# Altium schematic sheet DDR3 Interface.SchDoc
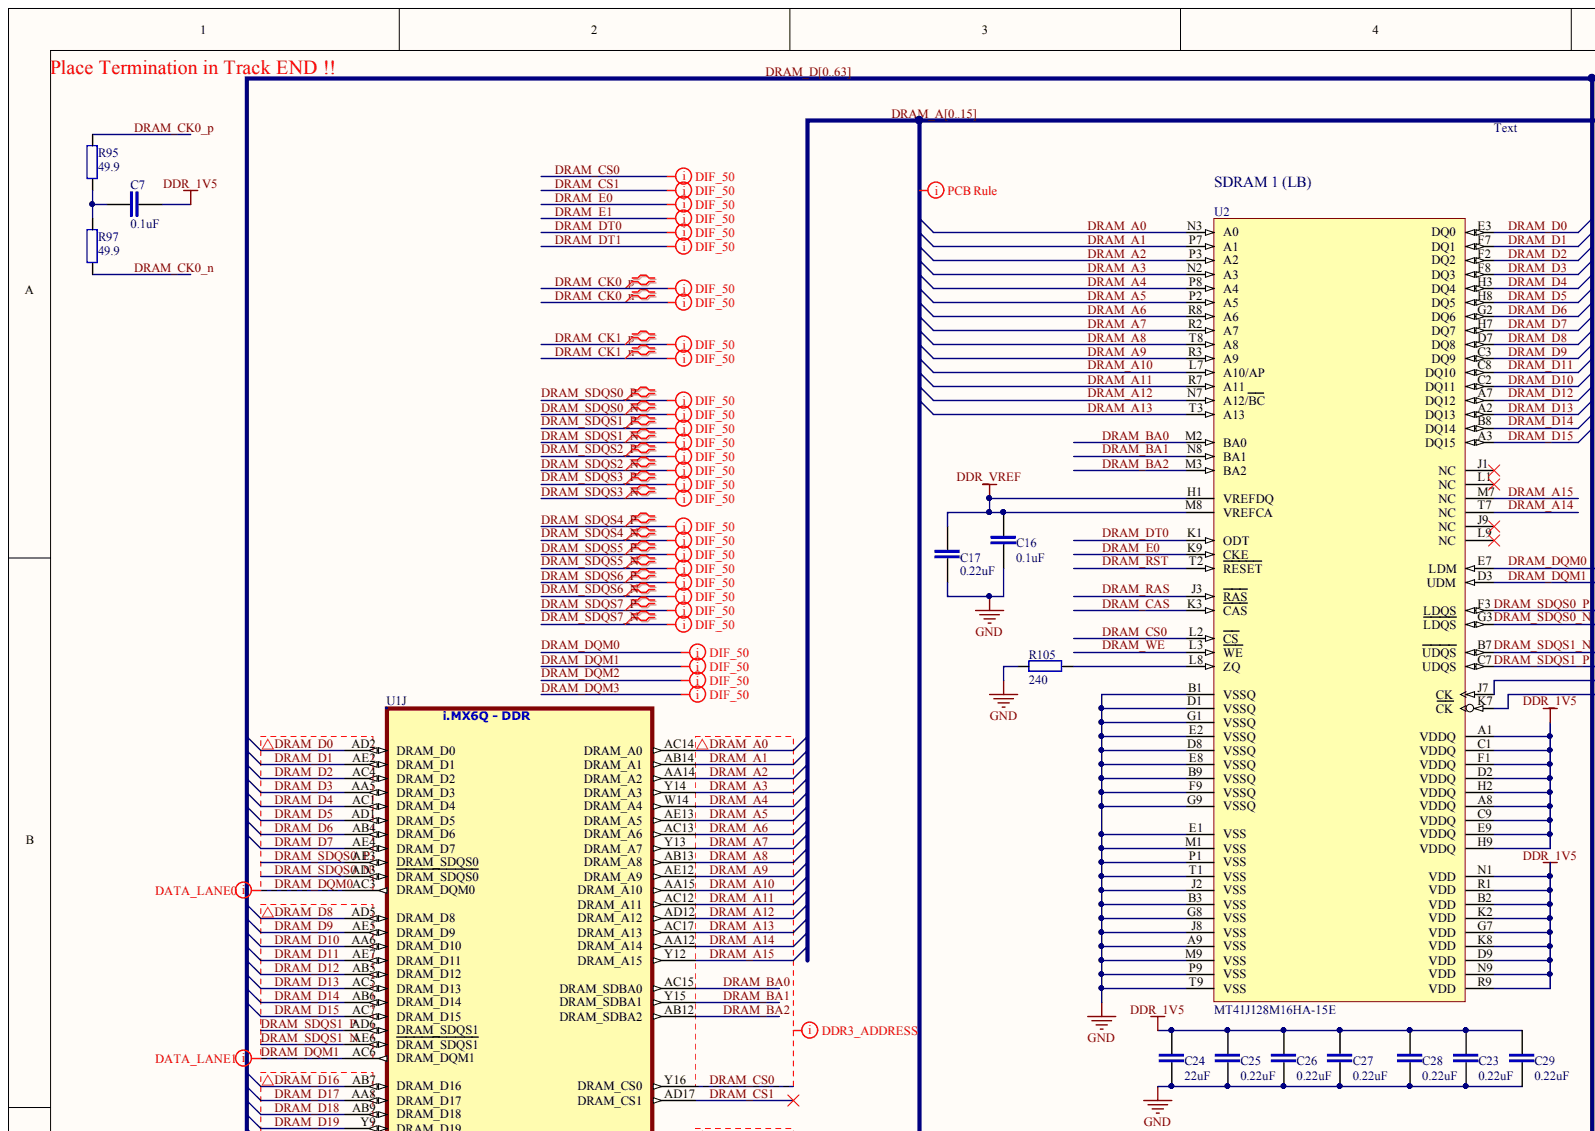
[diagram p0: DDR3 Interface.SchDoc, top left]
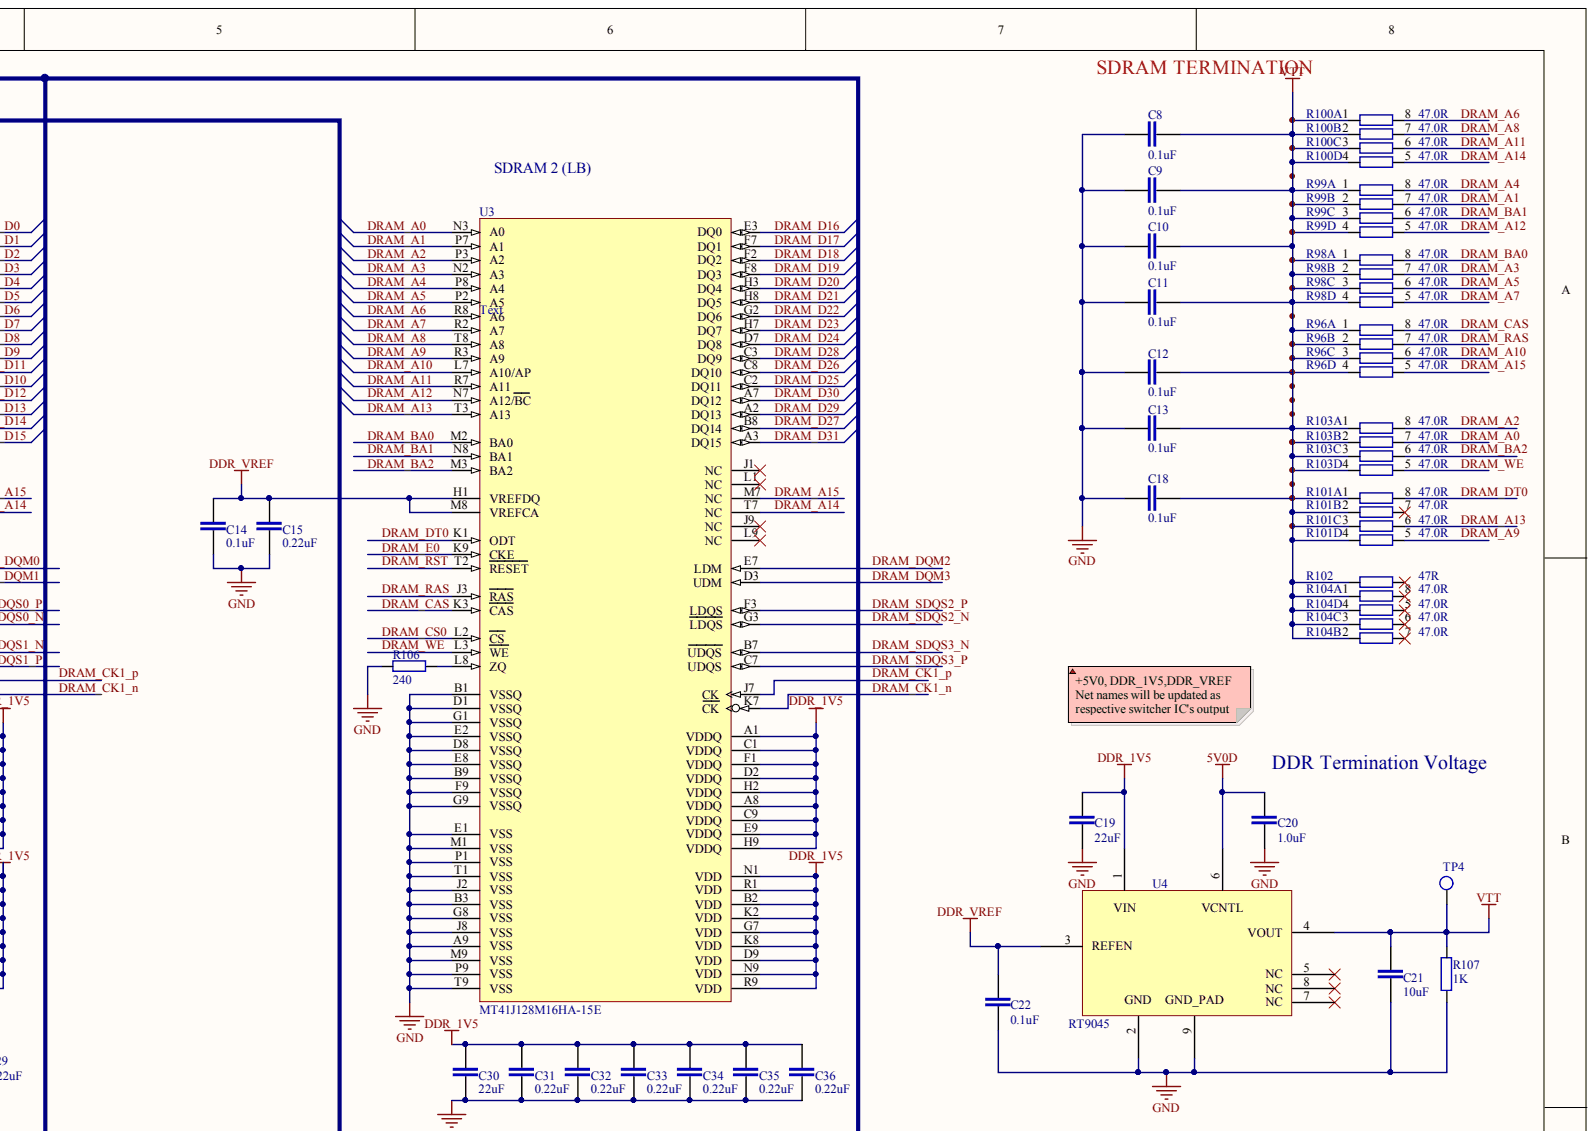
[diagram p1: DDR3 Interface.SchDoc, top right]
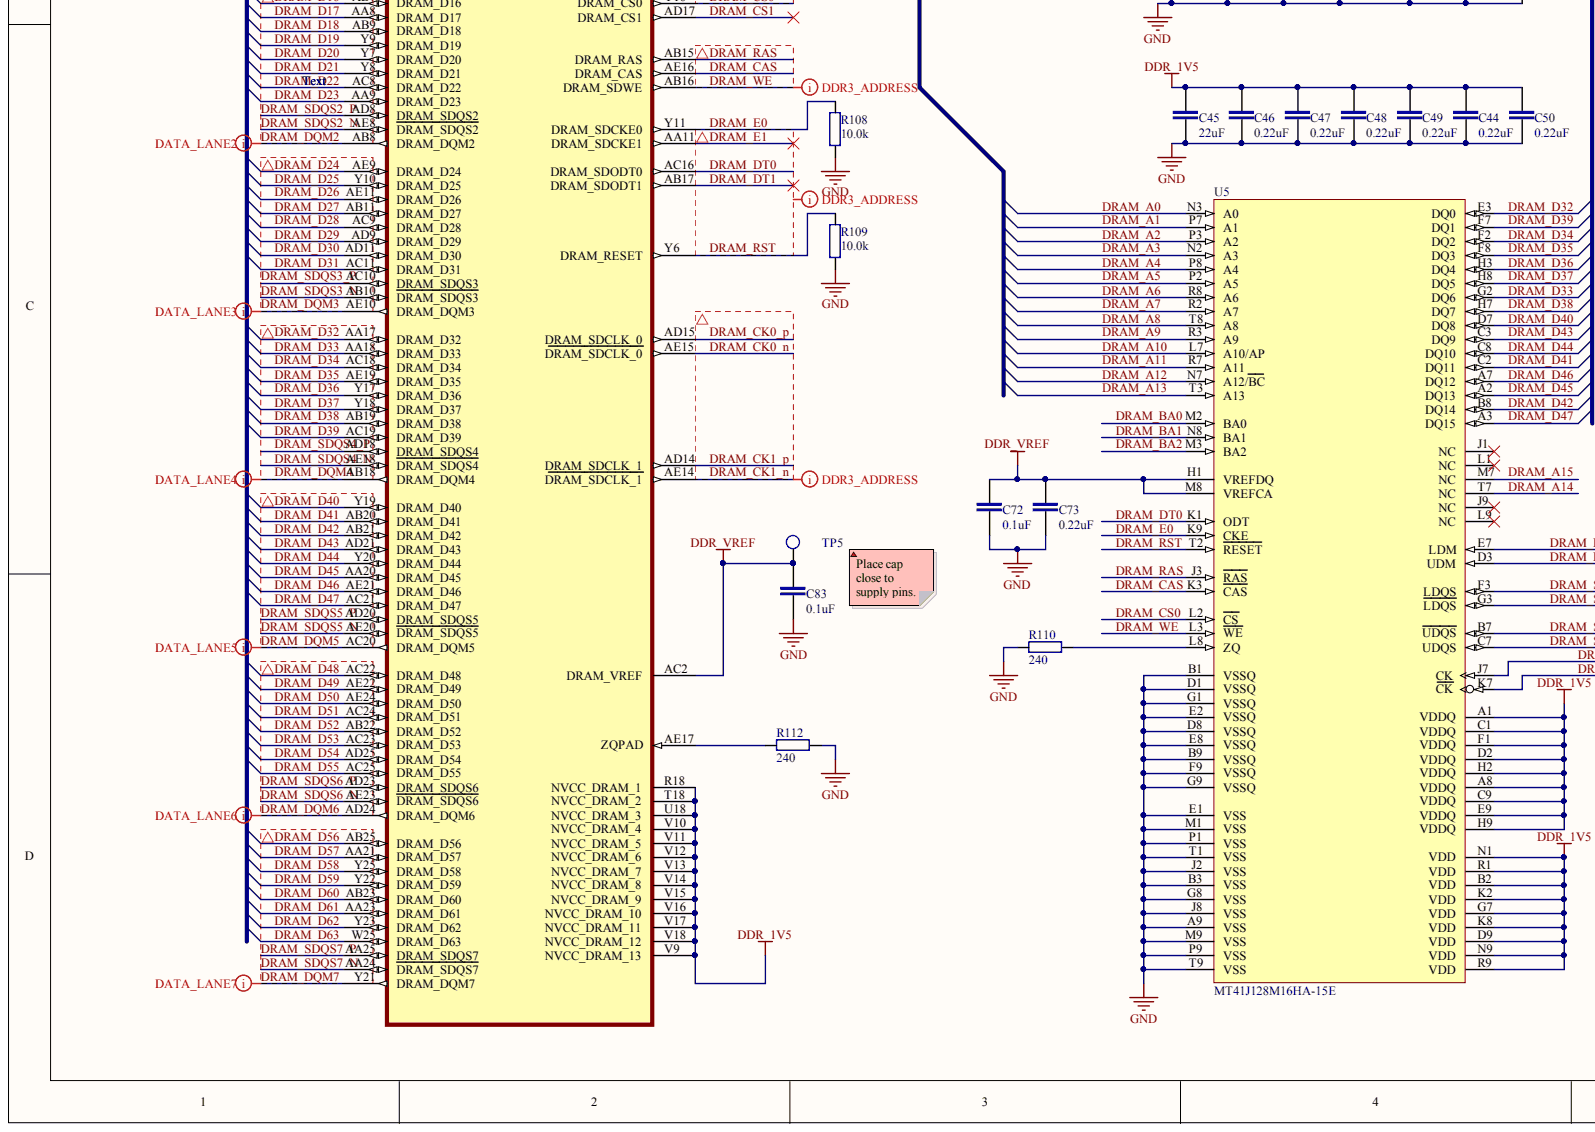
[diagram p2: DDR3 Interface.SchDoc, bottom left]
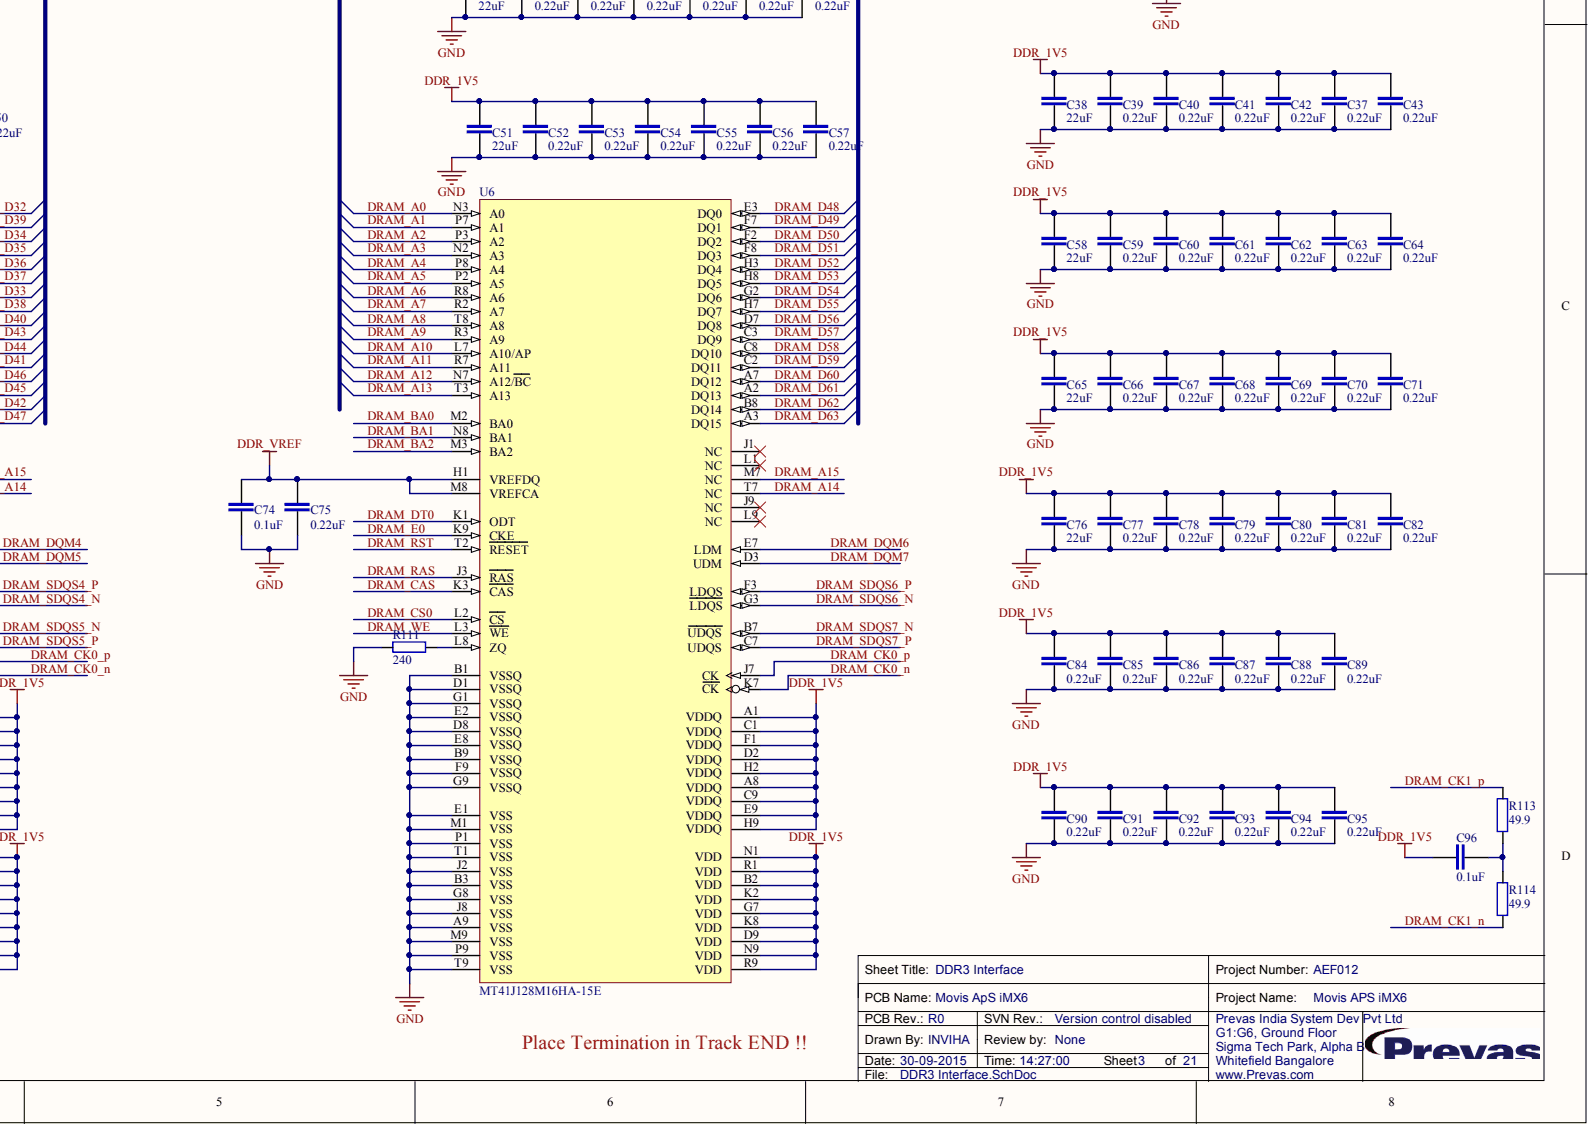
[diagram p3: DDR3 Interface.SchDoc, bottom right]

## Parts
C7  0.1uF  [CAPC1005X55N]
C8  0.1uF  [CAPC1005X55N]
C9  0.1uF  [CAPC1005X55N]
C10  0.1uF  [CAPC1005X55N]
C11  0.1uF  [CAPC1005X55N]
C12  0.1uF  [CAPC1005X55N]
C13  0.1uF  [CAPC1005X55N]
C14  0.1uF  [CAPC1005X55N]
C15  0.22uF  [CAPC1005X55N]
C16  0.1uF  [CAPC1005X55N]
C17  0.22uF  [CAPC1005X55N]
C18  0.1uF  [CAPC1005X55N]
C19  22uF  [CAPC3216X180N]
C20  1.0uF  [CAPC1608X90N]
C21  10uF  [CAPC1608X95N]
C22  0.1uF  [CAPC1005X55N]
C23  0.22uF  [CAPC1005X55N]
C24  22uF  [CAPC3216X180N]
C25  0.22uF  [CAPC1005X55N]
C26  0.22uF  [CAPC1005X55N]
C27  0.22uF  [CAPC1005X55N]
C28  0.22uF  [CAPC1005X55N]
C29  0.22uF  [CAPC1005X55N]
C30  22uF  [CAPC3216X180N]
C31  0.22uF  [CAPC1005X55N]
C32  0.22uF  [CAPC1005X55N]
C33  0.22uF  [CAPC1005X55N]
C34  0.22uF  [CAPC1005X55N]
C35  0.22uF  [CAPC1005X55N]
C36  0.22uF  [CAPC1005X55N]
C37  0.22uF  [CAPC1005X55N]
C38  22uF  [CAPC3216X180N]
C39  0.22uF  [CAPC1005X55N]
C40  0.22uF  [CAPC1005X55N]
C41  0.22uF  [CAPC1005X55N]
C42  0.22uF  [CAPC1005X55N]
C43  0.22uF  [CAPC1005X55N]
C44  0.22uF  [CAPC1005X55N]
C45  22uF  [CAPC3216X180N]
C46  0.22uF  [CAPC1005X55N]
C47  0.22uF  [CAPC1005X55N]
C48  0.22uF  [CAPC1005X55N]
C49  0.22uF  [CAPC1005X55N]
C50  0.22uF  [CAPC1005X55N]
C51  22uF  [CAPC3216X180N]
C52  0.22uF  [CAPC1005X55N]
C53  0.22uF  [CAPC1005X55N]
C54  0.22uF  [CAPC1005X55N]
C55  0.22uF  [CAPC1005X55N]
C56  0.22uF  [CAPC1005X55N]
C57  0.22uF  [CAPC1005X55N]
C58  22uF  [CAPC3216X180N]
C59  0.22uF  [CAPC1005X55N]
C60  0.22uF  [CAPC1005X55N]
C61  0.22uF  [CAPC1005X55N]
C62  0.22uF  [CAPC1005X55N]
C63  0.22uF  [CAPC1005X55N]
C64  0.22uF  [CAPC1005X55N]
C65  22uF  [CAPC3216X180N]
C66  0.22uF  [CAPC1005X55N]
C67  0.22uF  [CAPC1005X55N]
C68  0.22uF  [CAPC1005X55N]
C69  0.22uF  [CAPC1005X55N]
C70  0.22uF  [CAPC1005X55N]
C71  0.22uF  [CAPC1005X55N]
C72  0.1uF  [CAPC1005X55N]
C73  0.22uF  [CAPC1005X55N]
C74  0.1uF  [CAPC1005X55N]
C75  0.22uF  [CAPC1005X55N]
C76  22uF  [CAPC3216X180N]
C77  0.22uF  [CAPC1005X55N]
C78  0.22uF  [CAPC1005X55N]
C79  0.22uF  [CAPC1005X55N]
C80  0.22uF  [CAPC1005X55N]
C81  0.22uF  [CAPC1005X55N]
C82  0.22uF  [CAPC1005X55N]
C83  0.1uF  [CAPC1005X55N]
C84  0.22uF  [CAPC1005X55N]
C85  0.22uF  [CAPC1005X55N]
C86  0.22uF  [CAPC1005X55N]
C87  0.22uF  [CAPC1005X55N]
C88  0.22uF  [CAPC1005X55N]
C89  0.22uF  [CAPC1005X55N]
C90  0.22uF  [CAPC1005X55N]
C91  0.22uF  [CAPC1005X55N]
C92  0.22uF  [CAPC1005X55N]
C93  0.22uF  [CAPC1005X55N]
C94  0.22uF  [CAPC1005X55N]
C95  0.22uF  [CAPC1005X55N]
C96  0.1uF  [CAPC1005X55N]
R95  49.9  [RESC1005X40N]
R96  47.0R  [RESCAXS50P100X200X45-8N]  (parts A,B,C,D)
R97  49.9  [RESC1005X40N]
R98  47.0R  [RESCAXS50P100X200X45-8N]  (parts A,B,C,D)
R99  47.0R  [RESCAXS50P100X200X45-8N]  (parts A,B,C,D)
R100  47.0R  [RESCAXS50P100X200X45-8N]  (parts A,B,C,D)
R101  47.0R  [RESCAXS50P100X200X45-8N]  (parts A,B,C,D)
R102  47R  [RESC1608X55N]
R103  47.0R  [RESCAXS50P100X200X45-8N]  (parts A,B,C,D)
R104  47.0R  [RESCAXS50P100X200X45-8N]  (parts A,B,C,D)
R105  240  [RESC1005X40N]
R106  240  [RESC1005X40N]
R107  1K  [RESC1608X55N]
R108  10.0k  [RESC1005X40N]
R109  10.0k  [RESC1005X40N]
R110  240  [RESC1005X40N]
R111  240  [RESC1005X40N]
R112  240  [RESC1005X40N]
R113  49.9  [RESC1005X40N]
R114  49.9  [RESC1005X40N]
TP4  Testpoint  [tp_smd]  (value not drawn)  (no BOM)
TP5  Testpoint  [TP_SMD]  (value not drawn)  (no BOM)
U1  MCIMX6Q6AVT10AC  [BGA624C80P25X25_2100X2100X160]  (value not drawn)  (part J)
U2  MT41J128M16HA-15E  [BGA96C80P9X16_900X1400X120]
U3  MT41J128M16HA-15E  [BGA96C80P9X16_900X1400X120]
U4  RT9045  [SOIC127P600X175-9N]
U5  MT41J128M16HA-15E  [BGA96C80P9X16_900X1400X120]
U6  MT41J128M16HA-15E  [BGA96C80P9X16_900X1400X120]

## Nets
5V0D: C20.2 U4.6
DDR_1V5: C7.1 C19.2 C23.2 C24.2 C25.2 C26.2 C27.2 C28.2 C29.2 C30.2 C31.2 C32.2 C33.2 C34.2 C35.2 C36.2 C37.2 C38.2 C39.2 C40.2 C41.2 C42.2 C43.2 C44.2 C45.2 C46.2 C47.2 C48.2 C49.2 C50.2 C51.2 C52.2 C53.2 C54.2 C55.2 C56.2 C57.2 C58.2 C59.2 C60.2 C61.2 C62.2 C63.2 C64.2 C65.2 C66.2 C67.2 C68.2 C69.2 C70.2 C71.2 C76.2 C77.2 C78.2 C79.2 C80.2 C81.2 C82.2 C84.2 C85.2 C86.2 C87.2 C88.2 C89.2 C90.2 C91.2 C92.2 C93.2 C94.2 C95.2 C96.2 U1.R18(NVCC_DRAM_1) U1.T18(NVCC_DRAM_2) U1.U18(NVCC_DRAM_3) U1.V9(NVCC_DRAM_13) U1.V10(NVCC_DRAM_4) U1.V11(NVCC_DRAM_5) U1.V12(NVCC_DRAM_6) U1.V13(NVCC_DRAM_7) U1.V14(NVCC_DRAM_8) U1.V15(NVCC_DRAM_9) U1.V16(NVCC_DRAM_10) U1.V17(NVCC_DRAM_11) U1.V18(NVCC_DRAM_12) U2.A1(VDDQ) U2.A8(VDDQ) U2.B2(VDD) U2.C1(VDDQ) U2.C9(VDDQ) U2.D2(VDDQ) U2.D9(VDD) U2.E9(VDDQ) U2.F1(VDDQ) U2.G7(VDD) U2.H2(VDDQ) U2.H9(VDDQ) U2.K2(VDD) U2.K8(VDD) U2.N1(VDD) U2.N9(VDD) U2.R1(VDD) U2.R9(VDD) U3.A1(VDDQ) U3.A8(VDDQ) U3.B2(VDD) U3.C1(VDDQ) U3.C9(VDDQ) U3.D2(VDDQ) U3.D9(VDD) U3.E9(VDDQ) U3.F1(VDDQ) U3.G7(VDD) U3.H2(VDDQ) U3.H9(VDDQ) U3.K2(VDD) U3.K8(VDD) U3.N1(VDD) U3.N9(VDD) U3.R1(VDD) U3.R9(VDD) U4.1 U5.A1(VDDQ) U5.A8(VDDQ) U5.B2(VDD) U5.C1(VDDQ) U5.C9(VDDQ) U5.D2(VDDQ) U5.D9(VDD) U5.E9(VDDQ) U5.F1(VDDQ) U5.G7(VDD) U5.H2(VDDQ) U5.H9(VDDQ) U5.K2(VDD) U5.K8(VDD) U5.N1(VDD) U5.N9(VDD) U5.R1(VDD) U5.R9(VDD) U6.A1(VDDQ) U6.A8(VDDQ) U6.B2(VDD) U6.C1(VDDQ) U6.C9(VDDQ) U6.D2(VDDQ) U6.D9(VDD) U6.E9(VDDQ) U6.F1(VDDQ) U6.G7(VDD) U6.H2(VDDQ) U6.H9(VDDQ) U6.K2(VDD) U6.K8(VDD) U6.N1(VDD) U6.N9(VDD) U6.R1(VDD) U6.R9(VDD)
DDR_VREF: C14.2 C15.2 C16.2 C17.2 C22.2 C72.2 C73.2 C74.2 C75.2 C83.2 TP5.1 U1.AC2(DRAM_VREF) U2.H1(VREFDQ) U2.M8(VREFCA) U3.H1(VREFDQ) U3.M8(VREFCA) U4.3(REFEN) U5.H1(VREFDQ) U5.M8(VREFCA) U6.H1(VREFDQ) U6.M8(VREFCA)
GND: C8.2 C9.2 C10.2 C11.2 C12.2 C13.2 C14.1 C15.1 C16.1 C17.1 C18.2 C19.1 C20.1 C21.1 C22.1 C23.1 C24.1 C25.1 C26.1 C27.1 C28.1 C29.1 C30.1 C31.1 C32.1 C33.1 C34.1 C35.1 C36.1 C37.1 C38.1 C39.1 C40.1 C41.1 C42.1 C43.1 C44.1 C45.1 C46.1 C47.1 C48.1 C49.1 C50.1 C51.1 C52.1 C53.1 C54.1 C55.1 C56.1 C57.1 C58.1 C59.1 C60.1 C61.1 C62.1 C63.1 C64.1 C65.1 C66.1 C67.1 C68.1 C69.1 C70.1 C71.1 C72.1 C73.1 C74.1 C75.1 C76.1 C77.1 C78.1 C79.1 C80.1 C81.1 C82.1 C83.1 C84.1 C85.1 C86.1 C87.1 C88.1 C89.1 C90.1 C91.1 C92.1 C93.1 C94.1 C95.1 R105.1 R106.1 R107.1 R108.1 R109.1 R110.1 R111.1 R112.2 U2.A9(VSS) U2.B1(VSSQ) U2.B3(VSS) U2.B9(VSSQ) U2.D1(VSSQ) U2.D8(VSSQ) U2.E1(VSS) U2.E2(VSSQ) U2.E8(VSSQ) U2.F9(VSSQ) U2.G1(VSSQ) U2.G8(VSS) U2.G9(VSSQ) U2.J2(VSS) U2.J8(VSS) U2.M1(VSS) U2.M9(VSS) U2.P1(VSS) U2.P9(VSS) U2.T1(VSS) U2.T9(VSS) U3.A9(VSS) U3.B1(VSSQ) U3.B3(VSS) U3.B9(VSSQ) U3.D1(VSSQ) U3.D8(VSSQ) U3.E1(VSS) U3.E2(VSSQ) U3.E8(VSSQ) U3.F9(VSSQ) U3.G1(VSSQ) U3.G8(VSS) U3.G9(VSSQ) U3.J2(VSS) U3.J8(VSS) U3.M1(VSS) U3.M9(VSS) U3.P1(VSS) U3.P9(VSS) U3.T1(VSS) U3.T9(VSS) U4.2 U4.9 U5.A9(VSS) U5.B1(VSSQ) U5.B3(VSS) U5.B9(VSSQ) U5.D1(VSSQ) U5.D8(VSSQ) U5.E1(VSS) U5.E2(VSSQ) U5.E8(VSSQ) U5.F9(VSSQ) U5.G1(VSSQ) U5.G8(VSS) U5.G9(VSSQ) U5.J2(VSS) U5.J8(VSS) U5.M1(VSS) U5.M9(VSS) U5.P1(VSS) U5.P9(VSS) U5.T1(VSS) U5.T9(VSS) U6.A9(VSS) U6.B1(VSSQ) U6.B3(VSS) U6.B9(VSSQ) U6.D1(VSSQ) U6.D8(VSSQ) U6.E1(VSS) U6.E2(VSSQ) U6.E8(VSSQ) U6.F9(VSSQ) U6.G1(VSSQ) U6.G8(VSS) U6.G9(VSSQ) U6.J2(VSS) U6.J8(VSS) U6.M1(VSS) U6.M9(VSS) U6.P1(VSS) U6.P9(VSS) U6.T1(VSS) U6.T9(VSS)
VTT: C8.1 C9.1 C10.1 C11.1 C12.1 C13.1 C18.1 C21.2 R96.1 R96.2 R96.3 R96.4 R98.1 R98.2 R98.3 R98.4 R99.1 R99.2 R99.3 R99.4 R100.1 R100.2 R100.3 R100.4 R101.1 R101.2 R101.3 R101.4 R102.1 R103.1 R103.2 R103.3 R103.4 R104.1 R104.2 R104.3 R104.4 R107.2 TP4.1 U4.4(VOUT)
DRAM_A0: R103.7 U1.AC14(DRAM_A0) U2.N3(A0) U3.N3(A0) U5.N3(A0) U6.N3(A0)  [also labelled DRAM_A]
DRAM_A1: R99.7 U1.AB14(DRAM_A1) U2.P7(A1) U3.P7(A1) U5.P7(A1) U6.P7(A1)  [also labelled DRAM_A]
DRAM_A2: R103.8 U1.AA14(DRAM_A2) U2.P3(A2) U3.P3(A2) U5.P3(A2) U6.P3(A2)  [also labelled DRAM_A]
DRAM_A3: R98.7 U1.Y14(DRAM_A3) U2.N2(A3) U3.N2(A3) U5.N2(A3) U6.N2(A3)  [also labelled DRAM_A]
DRAM_A4: R99.8 U1.W14(DRAM_A4) U2.P8(A4) U3.P8(A4) U5.P8(A4) U6.P8(A4)  [also labelled DRAM_A]
DRAM_A5: R98.6 U1.AE13(DRAM_A5) U2.P2(A5) U3.P2(A5) U5.P2(A5) U6.P2(A5)  [also labelled DRAM_A]
DRAM_A6: R100.8 U1.AC13(DRAM_A6) U2.R8(A6) U3.R8(Text) U5.R8(A6) U6.R8(A6)  [also labelled DRAM_A]
DRAM_A7: R98.5 U1.Y13(DRAM_A7) U2.R2(A7) U3.R2(A7) U5.R2(A7) U6.R2(A7)  [also labelled DRAM_A]
DRAM_A8: R100.7 U1.AB13(DRAM_A8) U2.T8(A8) U3.T8(A8) U5.T8(A8) U6.T8(A8)  [also labelled DRAM_A]
DRAM_A9: R101.5 U1.AE12(DRAM_A9) U2.R3(A9) U3.R3(A9) U5.R3(A9) U6.R3(A9)  [also labelled DRAM_A]
DRAM_A10: R96.6 U1.AA15(DRAM_A10) U2.L7(A10/AP) U3.L7(A10/AP) U5.L7(A10/AP) U6.L7(A10/AP)  [also labelled DRAM_A]
DRAM_A11: R100.6 U1.AC12(DRAM_A11) U2.R7(A11) U3.R7(A11) U5.R7(A11) U6.R7(A11)  [also labelled DRAM_A]
DRAM_A12: R99.5 U1.AD12(DRAM_A12) U2.N7(A12/BC) U3.N7(A12/BC) U5.N7(A12/BC) U6.N7(A12/BC)  [also labelled DRAM_A]
DRAM_A13: R101.6 U1.AC17(DRAM_A13) U2.T3(A13) U3.T3(A13) U5.T3(A13) U6.T3(A13)  [also labelled DRAM_A]
DRAM_A14: R100.5 U1.AA12(DRAM_A14) U2.T7(NC) U3.T7(NC) U5.T7(NC) U6.T7(NC)  [also labelled DRAM_A]
DRAM_A15: R96.5 U1.Y12(DRAM_A15) U2.M7(NC) U3.M7(NC) U5.M7(NC) U6.M7(NC)  [also labelled DRAM_A]
DRAM_BA0: R98.8 U1.AC15(DRAM_SDBA0) U2.M2(BA0) U3.M2(BA0) U5.M2(BA0) U6.M2(BA0)
DRAM_BA1: R99.6 U1.Y15(DRAM_SDBA1) U2.N8(BA1) U3.N8(BA1) U5.N8(BA1) U6.N8(BA1)
DRAM_BA2: R103.6 U1.AB12(DRAM_SDBA2) U2.M3(BA2) U3.M3(BA2) U5.M3(BA2) U6.M3(BA2)
DRAM_CAS: R96.8 U1.AE16(DRAM_CAS) U2.K3(CAS) U3.K3(CAS) U5.K3(CAS) U6.K3(CAS)
DRAM_CK0_N: R97.1 U1.AE15(DRAM_SDCLK_0) U5.K7(CK) U6.K7(CK)
DRAM_CK0_P: R95.2 U1.AD15(DRAM_SDCLK_0) U5.J7(CK) U6.J7(CK)
DRAM_CK1_N: R114.1 U1.AE14(DRAM_SDCLK_1) U2.K7(CK) U3.K7(CK)
DRAM_CK1_P: R113.2 U1.AD14(DRAM_SDCLK_1) U2.J7(CK) U3.J7(CK)
DRAM_CS0: U1.Y16(DRAM_CS0) U2.L2(CS) U3.L2(CS) U5.L2(CS) U6.L2(CS)
DRAM_CS1: U1.AD17(DRAM_CS1)
DRAM_D0: U1.AD2(DRAM_D0) U2.E3(DQ0)  [also labelled DRAM_D]
DRAM_D1: U1.AE2(DRAM_D1) U2.F7(DQ1)  [also labelled DRAM_D]
DRAM_D2: U1.AC4(DRAM_D2) U2.F2(DQ2)  [also labelled DRAM_D]
DRAM_D3: U1.AA5(DRAM_D3) U2.F8(DQ3)  [also labelled DRAM_D]
DRAM_D4: U1.AC1(DRAM_D4) U2.H3(DQ4)  [also labelled DRAM_D]
DRAM_D5: U1.AD1(DRAM_D5) U2.H8(DQ5)  [also labelled DRAM_D]
DRAM_D6: U1.AB4(DRAM_D6) U2.G2(DQ6)  [also labelled DRAM_D]
DRAM_D7: U1.AE4(DRAM_D7) U2.H7(DQ7)  [also labelled DRAM_D]
DRAM_D8: U1.AD5(DRAM_D8) U2.D7(DQ8)  [also labelled DRAM_D]
DRAM_D9: U1.AE5(DRAM_D9) U2.C3(DQ9)  [also labelled DRAM_D]
DRAM_D10: U1.AA6(DRAM_D10) U2.C2(DQ11)  [also labelled DRAM_D]
DRAM_D11: U1.AE7(DRAM_D11) U2.C8(DQ10)  [also labelled DRAM_D]
DRAM_D12: U1.AB5(DRAM_D12) U2.A7(DQ12)  [also labelled DRAM_D]
DRAM_D13: U1.AC5(DRAM_D13) U2.A2(DQ13)  [also labelled DRAM_D]
DRAM_D14: U1.AB6(DRAM_D14) U2.B8(DQ14)  [also labelled DRAM_D]
DRAM_D15: U1.AC7(DRAM_D15) U2.A3(DQ15)  [also labelled DRAM_D]
DRAM_D16: U1.AB7(DRAM_D16) U3.E3(DQ0)  [also labelled DRAM_D]
DRAM_D17: U1.AA8(DRAM_D17) U3.F7(DQ1)  [also labelled DRAM_D]
DRAM_D18: U1.AB9(DRAM_D18) U3.F2(DQ2)  [also labelled DRAM_D]
DRAM_D19: U1.Y9(DRAM_D19) U3.F8(DQ3)  [also labelled DRAM_D]
DRAM_D20: U1.Y7(DRAM_D20) U3.H3(DQ4)  [also labelled DRAM_D]
DRAM_D21: U1.Y8(DRAM_D21) U3.H8(DQ5)  [also labelled DRAM_D]
DRAM_D22: U1.AC8(DRAM_D22) U3.G2(DQ6)  [also labelled DRAM_D]
DRAM_D23: U1.AA9(DRAM_D23) U3.H7(DQ7)  [also labelled DRAM_D]
DRAM_D24: U1.AE9(DRAM_D24) U3.D7(DQ8)  [also labelled DRAM_D]
DRAM_D25: U1.Y10(DRAM_D25) U3.C2(DQ11)  [also labelled DRAM_D]
DRAM_D26: U1.AE11(DRAM_D26) U3.C8(DQ10)  [also labelled DRAM_D]
DRAM_D27: U1.AB11(DRAM_D27) U3.B8(DQ14)  [also labelled DRAM_D]
DRAM_D28: U1.AC9(DRAM_D28) U3.C3(DQ9)  [also labelled DRAM_D]
DRAM_D29: U1.AD9(DRAM_D29) U3.A2(DQ13)  [also labelled DRAM_D]
DRAM_D30: U1.AD11(DRAM_D30) U3.A7(DQ12)  [also labelled DRAM_D]
DRAM_D31: U1.AC11(DRAM_D31) U3.A3(DQ15)  [also labelled DRAM_D]
DRAM_D32: U1.AA17(DRAM_D32) U5.E3(DQ0)  [also labelled DRAM_D]
DRAM_D33: U1.AA18(DRAM_D33) U5.G2(DQ6)  [also labelled DRAM_D]
DRAM_D34: U1.AC18(DRAM_D34) U5.F2(DQ2)  [also labelled DRAM_D]
DRAM_D35: U1.AE19(DRAM_D35) U5.F8(DQ3)  [also labelled DRAM_D]
DRAM_D36: U1.Y17(DRAM_D36) U5.H3(DQ4)  [also labelled DRAM_D]
DRAM_D37: U1.Y18(DRAM_D37) U5.H8(DQ5)  [also labelled DRAM_D]
DRAM_D38: U1.AB19(DRAM_D38) U5.H7(DQ7)  [also labelled DRAM_D]
DRAM_D39: U1.AC19(DRAM_D39) U5.F7(DQ1)  [also labelled DRAM_D]
DRAM_D40: U1.Y19(DRAM_D40) U5.D7(DQ8)  [also labelled DRAM_D]
DRAM_D41: U1.AB20(DRAM_D41) U5.C2(DQ11)  [also labelled DRAM_D]
DRAM_D42: U1.AB21(DRAM_D42) U5.B8(DQ14)  [also labelled DRAM_D]
DRAM_D43: U1.AD21(DRAM_D43) U5.C3(DQ9)  [also labelled DRAM_D]
DRAM_D44: U1.Y20(DRAM_D44) U5.C8(DQ10)  [also labelled DRAM_D]
DRAM_D45: U1.AA20(DRAM_D45) U5.A2(DQ13)  [also labelled DRAM_D]
DRAM_D46: U1.AE21(DRAM_D46) U5.A7(DQ12)  [also labelled DRAM_D]
DRAM_D47: U1.AC21(DRAM_D47) U5.A3(DQ15)  [also labelled DRAM_D]
DRAM_D48: U1.AC22(DRAM_D48) U6.E3(DQ0)  [also labelled DRAM_D]
DRAM_D49: U1.AE22(DRAM_D49) U6.F7(DQ1)  [also labelled DRAM_D]
DRAM_D50: U1.AE24(DRAM_D50) U6.F2(DQ2)  [also labelled DRAM_D]
DRAM_D51: U1.AC24(DRAM_D51) U6.F8(DQ3)  [also labelled DRAM_D]
DRAM_D52: U1.AB22(DRAM_D52) U6.H3(DQ4)  [also labelled DRAM_D]
DRAM_D53: U1.AC23(DRAM_D53) U6.H8(DQ5)  [also labelled DRAM_D]
DRAM_D54: U1.AD25(DRAM_D54) U6.G2(DQ6)  [also labelled DRAM_D]
DRAM_D55: U1.AC25(DRAM_D55) U6.H7(DQ7)  [also labelled DRAM_D]
DRAM_D56: U1.AB25(DRAM_D56) U6.D7(DQ8)  [also labelled DRAM_D]
DRAM_D57: U1.AA21(DRAM_D57) U6.C3(DQ9)  [also labelled DRAM_D]
DRAM_D58: U1.Y25(DRAM_D58) U6.C8(DQ10)  [also labelled DRAM_D]
DRAM_D59: U1.Y22(DRAM_D59) U6.C2(DQ11)  [also labelled DRAM_D]
DRAM_D60: U1.AB23(DRAM_D60) U6.A7(DQ12)  [also labelled DRAM_D]
DRAM_D61: U1.AA23(DRAM_D61) U6.A2(DQ13)  [also labelled DRAM_D]
DRAM_D62: U1.Y23(DRAM_D62) U6.B8(DQ14)  [also labelled DRAM_D]
DRAM_D63: U1.W25(DRAM_D63) U6.A3(DQ15)  [also labelled DRAM_D]
DRAM_DQM0: U1.AC3(DRAM_DQM0) U2.E7(LDM)
DRAM_DQM1: U1.AC6(DRAM_DQM1) U2.D3(UDM)
DRAM_DQM2: U1.AB8(DRAM_DQM2) U3.E7(LDM)
DRAM_DQM3: U1.AE10(DRAM_DQM3) U3.D3(UDM)
DRAM_DQM4: U1.AB18(DRAM_DQM4) U5.E7(LDM)
DRAM_DQM5: U1.AC20(DRAM_DQM5) U5.D3(UDM)
DRAM_DQM6: U1.AD24(DRAM_DQM6) U6.E7(LDM)
DRAM_DQM7: U1.Y21(DRAM_DQM7) U6.D3(UDM)
DRAM_DT0: R101.8 U1.AC16(DRAM_SDODT0) U2.K1(ODT) U3.K1(ODT) U5.K1(ODT) U6.K1(ODT)
DRAM_DT1: U1.AB17(DRAM_SDODT1)
DRAM_E0: R108.2 U1.Y11(DRAM_SDCKE0) U2.K9(CKE) U3.K9(CKE) U5.K9(CKE) U6.K9(CKE)
DRAM_E1: U1.AA11(DRAM_SDCKE1)
DRAM_RAS: R96.7 U1.AB15(DRAM_RAS) U2.J3(RAS) U3.J3(RAS) U5.J3(RAS) U6.J3(RAS)
DRAM_RST: R109.2 U1.Y6(DRAM_RESET) U2.T2(RESET) U3.T2(RESET) U5.T2(RESET) U6.T2(RESET)
DRAM_SDQS0_N: U1.AD3(DRAM_SDQS0) U2.G3(LDQS)
DRAM_SDQS0_P: U1.AE3(DRAM_SDQS0) U2.F3(LDQS)
DRAM_SDQS1_N: U1.AE6(DRAM_SDQS1) U2.B7(UDQS)
DRAM_SDQS1_P: U1.AD6(DRAM_SDQS1) U2.C7(UDQS)
DRAM_SDQS2_N: U1.AE8(DRAM_SDQS2) U3.G3(LDQS)
DRAM_SDQS2_P: U1.AD8(DRAM_SDQS2) U3.F3(LDQS)
DRAM_SDQS3_N: U1.AB10(DRAM_SDQS3) U3.B7(UDQS)
DRAM_SDQS3_P: U1.AC10(DRAM_SDQS3) U3.C7(UDQS)
DRAM_SDQS4_N: U1.AE18(DRAM_SDQS4) U5.G3(LDQS)
DRAM_SDQS4_P: U1.AD18(DRAM_SDQS4) U5.F3(LDQS)
DRAM_SDQS5_N: U1.AE20(DRAM_SDQS5) U5.B7(UDQS)
DRAM_SDQS5_P: U1.AD20(DRAM_SDQS5) U5.C7(UDQS)
DRAM_SDQS6_N: U1.AE23(DRAM_SDQS6) U6.G3(LDQS)
DRAM_SDQS6_P: U1.AD23(DRAM_SDQS6) U6.F3(LDQS)
DRAM_SDQS7_N: U1.AA24(DRAM_SDQS7) U6.B7(UDQS)
DRAM_SDQS7_P: U1.AA25(DRAM_SDQS7) U6.C7(UDQS)
DRAM_WE: R103.5 U1.AB16(DRAM_SDWE) U2.L3(WE) U3.L3(WE) U5.L3(WE) U6.L3(WE)
NetC7_2: C7.2 R95.1 R97.2
NetC96_1: C96.1 R113.1 R114.2
NetR105_2: R105.2 U2.L8(ZQ)
NetR106_2: R106.2 U3.L8(ZQ)
NetR110_2: R110.2 U5.L8(ZQ)
NetR111_2: R111.2 U6.L8(ZQ)
NetR112_1: R112.1 U1.AE17(ZQPAD)
N00142:
N00143:

Not connected: R101 7; R102 2; R104 5 6 7 8; U2 J1(NC) J9(NC) L1(NC) L9(NC); U3 J1(NC) J9(NC) L1(NC) L9(NC); U4 5(NC) 7(NC) 8(NC); U5 J1(NC) J9(NC) L1(NC) L9(NC); U6 J1(NC) J9(NC) L1(NC) L9(NC)

## Library data of the parts
C7-C14 C16 C18 C22 C72 C74 C83 C96 = INNISI-73: CAP CER 0.1UF 50V 10% X5R 0402; ref_part_type: /capacitor/non_polarized/ceramic/; source_manufacturer_1: TDK Corporation; source_manufacturer_1_pn: C1005X5R1H104K050BB; cae_altium_pcb_status: Prototype; Database Table Name: altium_default; db_rev: 7569; elec_temp_range: -55 to +85 C; phy_height: 0.55mm; phy_mounting: SMD; phy_packaging_type: 0402; ref_datasheet: INNISI-71; cae_altium_sch_status: Prototype; Component Kind: Standard; ComponentLink1Description: Datasheet; ComponentLink1URL: https://cop201.prevas.dk/viewvc/datasheet/INNISI-71.pdf?root=hw_components&view=co; db_created: 2015-08-03 03:34:59.0; db_last_updated: 03-08-2015 03:35:01; db_local_id: INNISI-73; db_status: Prototype; elec_tolerance: ±10%; elec_value: 0.1uF; elec_voltage_rating: 50V; phy_material: X5R; ref_keyword: CAP CER 0.1UF 50V 10% X5R 0402; Library Name: altium_mysql.dblib
C15 C17 C23 C25-C29 C31-C37 C39-C44 C46-C50 C52-C57 C59-C64 C66-C71 C73 C75 C77-C82 C84-C95 = innisi-76: CAP CER 0.22UF 16V 10% X7R 0402; ref_part_type: /capacitor/non_polarized/ceramic/; source_manufacturer_1: Murata; source_manufacturer_1_pn: GRM155R71C224KA12D; cae_altium_pcb_status: Prototype; Database Table Name: altium_default; db_rev: 7572; elec_temp_range: -55 to +125C; phy_height: 0.55mm; phy_mounting: SMD; phy_packaging_type: 0402; ref_datasheet: INNISI-73; ref_keyword: Ceramic capacitor X7R 0402; cae_altium_sch_status: Prototype; Component Kind: Standard; ComponentLink1Description: Datasheet; ComponentLink1URL: https://cop201.prevas.dk/viewvc/datasheet/INNISI-73.pdf?root=hw_components&view=co; db_created: 2015-08-03 04:28:56.0; db_last_updated: 03-08-2015 04:28:58; db_local_id: INNISI-76; db_status: Prototype; elec_tolerance: 10%; elec_value: 0.22uF; elec_voltage_rating: 16V; phy_material: X7R; Library Name: altium_mysql.dblib
C19 C24 C30 C38 C45 C51 C58 C65 C76 = INNISI-77: CAP CER 22UF 25V 10% X5R 1206; ref_part_type: /capacitor/non_polarized/ceramic/; source_manufacturer_1: Murata Electronics; source_manufacturer_1_pn: GRM31CR61E226KE15L; cae_altium_pcb_status: Prototype; Database Table Name: altium_default; db_rev: 7573; elec_temp_range: -55 to +85 C; phy_height: 1.8mm; phy_mounting: SMD; phy_packaging_type: 1206; ref_datasheet: INNISI-74; ref_keyword: Multilayer chip capacitor; cae_altium_sch_status: Prototype; Component Kind: Standard; ComponentLink1Description: Datasheet; ComponentLink1URL: https://cop201.prevas.dk/viewvc/datasheet/INNISI-74.pdf?root=hw_components&view=co; db_created: 2015-08-03 04:56:21.0; db_last_updated: 03-08-2015 04:56:22; db_local_id: INNISI-77; db_status: Prototype; elec_tolerance: 10%; elec_value: 22uF; elec_voltage_rating: 25V; phy_material: X5R; Library Name: altium_mysql.dblib
C20 = INNISI-79: CAP CER 1UF 16V 10% X7R 0603; ref_part_type: /capacitor/non_polarized/ceramic/; source_manufacturer_1: Murata; source_manufacturer_1_pn: GRM188R71C105KE15D; cae_altium_pcb_status: Prototype; Database Table Name: altium_default; db_rev: 7576; elec_temp_range: -55 to +125; phy_height: 0.90mm; phy_mounting: SMD; phy_packaging_type: 0603 (1608 Metric); ref_datasheet: INNISI-33; ref_keyword: Ceramic Capacitor 0603; cae_altium_sch_status: Prototype; Component Kind: Standard; ComponentLink1Description: Datasheet; ComponentLink1URL: https://cop201.prevas.dk/viewvc/datasheet/INNISI-33.pdf?root=hw_components&view=co; db_created: 2015-08-03 05:39:06.0; db_last_updated: 03-08-2015 05:39:08; db_local_id: INNISI-79; db_status: Prototype; elec_tolerance: 10%; elec_value: 1.0uF; elec_voltage_rating: 16V; phy_material: X7R; Library Name: altium_mysql.dblib
C21 = innisi-78: CAP CER 10UF 16V 10% X5R 0603; ref_part_type: /capacitor/non_polarized/ceramic/; source_manufacturer_1: Murata; source_manufacturer_1_pn: GRM188R61C106KAALD; cae_altium_pcb_status: Prototype; Database Table Name: altium_default; db_rev: 7574; elec_temp_range: -55 to +85C; phy_height: 0.95mm; phy_mounting: SMD; phy_packaging_type: 0603; ref_datasheet: INNISI-75; ref_keyword: Ceramic capacitor X5R 0603; cae_altium_sch_status: Prototype; Component Kind: Standard; ComponentLink1Description: Datasheet; ComponentLink1URL: https://cop201.prevas.dk/viewvc/datasheet/INNISI-75.pdf?root=hw_components&view=co; db_created: 2015-08-03 05:08:42.0; db_last_updated: 03-08-2015 05:08:44; db_local_id: INNISI-78; db_status: Prototype; elec_tolerance: 10%; elec_value: 10uF; elec_voltage_rating: 16V; phy_material: X5R; Library Name: altium_mysql.dblib
R95 R97 R113 R114 = SYS-1377: ref_part_type: /resistor/fixed/; source_manufacturer_1: Phycomp; source_manufacturer_1_pn: 2322706*4999L; cae_altium_pcb_status: Prototype; Database Table Name: altium_default; db_rev: 3; elec_current_rating: 1A; elec_power_rating: 0.063W; elec_temp_range: -55 to 155; elec_tolerance: 1%; elec_voltage_rating: 50V; phy_height: 0.40; phy_material: Thick film; phy_mounting: SMD; phy_packaging_type: 0402; ref_datasheet: CHKJ-4; ref_keyword: chip resistor; cae_altium_sch_status: Prototype; Component Kind: Standard; ComponentLink1Description: Datasheet; ComponentLink1URL: https://cop201.prevas.dk/viewvc/datasheet/CHKJ-4.pdf?root=hw_components&view=co; db_created: 2008-03-25 18:43:51; db_last_updated: 25-03-2008 18:44:50; db_local_id: SYS-1377; db_status: Prototype; elec_value: 49.9; Library Name: altium_mysql.dblib
R96 R98-R101 R103 R104 = CHPE-170: Resistor Array Convex 4 x 0402; ref_part_type: /resistor/fixed/; source_manufacturer_1: VISHAY; source_manufacturer_1_pn: CRA04S08347R0FTD; cae_altium_pcb_status: Prototype; Database Table Name: altium_default; db_rev: 3865; elec_power_rating: 62.5mW; elec_temp_range: -55 to +155; elec_tolerance: 1%; elec_voltage_rating: 50V; phy_height: 0.45mm; phy_material: Thick film; phy_mounting: SMD; phy_packaging_type: 4x0402; ref_datasheet: GEPW-14; ref_keyword: Thick Film Resistor Array; cae_altium_sch_status: Prototype; Component Kind: Standard; ComponentLink1Description: Datasheet; ComponentLink1URL: https://cop201.prevas.dk/viewvc/datasheet/GEPW-14.pdf?root=hw_components&view=co; db_created: 2011-08-18 21:51:28.0; db_last_updated: 18-08-2011 21:51:29; db_local_id: CHPE-170; db_status: Prototype; elec_value: 47.0R; Library Name: altium_mysql.dblib
R102 = INVIHA-9: RES SMD 47 OHM 1% 1/10W 0603; ref_part_type: /resistor/fixed/; source_manufacturer_1: Yageo; source_manufacturer_1_pn: RC0603FR-0747RL; cae_altium_pcb_status: Prototype; Database Table Name: altium_default; db_rev: 7587; elec_power_rating: 0.1W; elec_temp_range: -55 to +155° C; phy_height: 0.55mm; phy_packaging_type: 0603; ref_datasheet: INVIHA-2; ref_keyword: Chip Resistor; cae_altium_sch_status: Prototype; Component Kind: Standard; ComponentLink1Description: Datasheet; ComponentLink1URL: https://cop201.prevas.dk/viewvc/datasheet/INVIHA-2.pdf?root=hw_components&view=co; db_created: 2015-08-03 06:33:51.0; db_last_updated: 03-08-2015 6:33:53 AM; db_local_id: INVIHA-9; db_status: Prototype; elec_tolerance: 1%; elec_value: 47R; phy_mounting: SMD; Library Name: altium_mysql.dblib
R105 R106 R110-R112 = SYS-2155: ref_part_type: /resistor/fixed/; source_manufacturer_1: Phycomp; source_manufacturer_1_pn: 2322706*2401L; cae_altium_pcb_status: Prototype; Database Table Name: altium_default; db_rev: 631; elec_current_rating: 1A; elec_power_rating: 0.063W; elec_temp_range: -55 to 155; elec_tolerance: 1%; elec_voltage_rating: 50V; phy_height: 0.40; phy_material: Thick film; phy_mounting: SMD; phy_packaging_type: 0402; ref_datasheet: CHKJ-4; ref_keyword: chip resistor; cae_altium_sch_status: Prototype; Component Kind: Standard; ComponentLink1Description: Datasheet; ComponentLink1URL: https://cop201.prevas.dk/viewvc/datasheet/CHKJ-4.pdf?root=hw_components&view=co; db_created: 2008-11-27 22:59:01; db_last_updated: 27-11-2008 23:03:51; db_local_id: SYS-2155; db_status: Prototype; elec_value: 240; Library Name: altium_mysql.dblib
R107 = INVIHA-11: RES SMD 1K OHM 1% 1/10W 0603; ref_part_type: /resistor/fixed/; source_manufacturer_1: Yageo; source_manufacturer_1_pn: RC0603FR-071KL; cae_altium_pcb_status: Prototype; Database Table Name: altium_default; db_rev: 7590; elec_power_rating: 0.1W; elec_temp_range: -55 to +155° C; phy_height: 0.55mm; phy_packaging_type: 0603; ref_datasheet: INVIHA-2; ref_keyword: Chip Resistor; cae_altium_sch_status: Prototype; Component Kind: Standard; ComponentLink1Description: Datasheet; ComponentLink1URL: https://cop201.prevas.dk/viewvc/datasheet/INVIHA-2.pdf?root=hw_components&view=co; db_created: 2015-08-03 06:39:44.0; db_last_updated: 03-08-2015 6:39:46 AM; db_local_id: INVIHA-11; db_status: Prototype; elec_tolerance: 1%; elec_value: 1K; phy_mounting: SMD; Library Name: altium_mysql.dblib
R108 R109 = SYS-1598: ref_part_type: /resistor/fixed/; source_manufacturer_1: Phycomp; source_manufacturer_1_pn: RC0402FR-**10KL; cae_altium_pcb_status: Prototype; Database Table Name: altium_default; db_rev: 1704; elec_current_rating: 1A; elec_power_rating: 0.063W; elec_temp_range: -55 to 155; elec_tolerance: 1%; elec_voltage_rating: 50V; phy_height: 0.40; phy_material: Thick film; phy_mounting: SMD; phy_packaging_type: 0402; ref_datasheet: CHKJ-4; ref_keyword: chip resistor; cae_altium_sch_status: Prototype; Component Kind: Standard; ComponentLink1Description: Datasheet; ComponentLink1URL: https://cop201.prevas.dk/viewvc/datasheet/CHKJ-4.pdf?root=hw_components&view=co; db_created: 2008-03-25 18:43:54; db_last_updated: 03-09-2009 20:32:44; db_local_id: SYS-1598; db_status: Prototype; elec_value: 10.0k; Library Name: altium_mysql.dblib
TP4 TP5 = JEPE-114: Test Point SMD; ref_part_type: /pcb_item/; cae_altium_pcb_status: Prototype; Database Table Name: altium_default; db_rev: 3281; cae_altium_sch_status: Prototype; ComponentLink1Description: Datasheet; db_created: 2008-08-18 11:39:42; db_last_updated: 23-03-2011 14:14:06; db_local_id: JEPE-114; db_status: Prototype; elec_value: Test Point; phy_mounting: SMD; ref_keyword: Test  Point; Library Name: altium_mysql.dblib
U1 = INRAAN-5: i.MX 6Dual/6Quad; ref_part_type: /integrated/digital/processor/general/; AGILE_PN: 344-01218; CAD_APPROVED: Yes; cae_altium_pcb_status: Prototype; CLASS: IC; Database Table Name: altium_default; db_rev: 6247; elec_temp_range: -40 to +105; elec_voltage_rating: 1.5V; MFG_NAME01: FREESCALE SEMICONDUCTOR; phy_height: 1.85; phy_mounting: SMD; phy_packaging_type: FCPBGA96_624; ref_datasheet: INRAAN-6; cae_altium_sch_status: Prototype; Component Kind: Standard; ComponentLink1Description: Datasheet; ComponentLink1URL: https://cop201.prevas.dk/viewvc/datasheet/INRAAN-6.pdf?root=hw_components&view=co; db_created: 2013-10-21 12:25:57.0; db_last_updated: 27-12-2013 09:32:57; db_local_id: INRAAN-5; db_status: Prototype; elec_value: MCIMX6Q6AVT10AC; HEIGHT: 2.16MM; MFG_PN01: MCIMX6Q6AVT10AC; ref_keyword: i.MX 6Dual/6Quad; ROHS: Yes; source_manufacturer_1: Freescale Semiconductor; source_manufacturer_1_pn: MCIMX6Q6AVT10AC; Library Name: altium_mysql.dblib
U2 U3 U5 U6 = CHPE-135: 16 Meg x 16 X 16 DDR3 SDRAM; ref_part_type: /integrated/digital/memory/volatile/dynamic/synchronous/; source_manufacturer_1: Micron; cae_altium_pcb_status: Prototype; Database Table Name: altium_default; db_rev: 3587; elec_temp_range: 0 to 95°C; elec_voltage_rating: 1.5V; phy_height: 0.8; phy_mounting: SMD; phy_packaging_type: FBGA96; ref_datasheet: TELA-45; cae_altium_sch_status: Prototype; Component Kind: Standard; ComponentLink1Description: Datasheet; ComponentLink1URL: https://cop201.prevas.dk/viewvc/datasheet/TELA-45.pdf?root=hw_components&view=co; db_created: 2011-05-31 18:41:14.0; db_last_updated: 08-06-2011 21:33:03; db_local_id: CHPE-135; db_status: Prototype; elec_value: MT41J128M16HA-15E; ref_keyword: DDR3 SDRAM; source_manufacturer_1_pn: MT41J128M16HA-15E; Library Name: altium_mysql.dblib
U4 = INRAAN-228: Cost-Effective, 1.8A Sink/Source Bus Termination Regulator; ref_part_type: /integrated/analog/power/supply/linear/; source_manufacturer_1: Richtek; cae_altium_pcb_status: Prototype; Database Table Name: altium_default; db_rev: 6946; elec_temp_range: -40C to 125C; elec_voltage_rating: 1V to 5.5V; phy_height: 1.753mm; phy_mounting: SMD; phy_packaging_type: SOP-8; ref_datasheet: INRAAN-213; ref_keyword: DDR Termination Regulator; cae_altium_sch_status: Prototype; Component Kind: Standard; ComponentLink1Description: Datasheet; ComponentLink1URL: https://cop201.prevas.dk/viewvc/datasheet/INRAAN-213.pdf?root=hw_components&view=co; db_created: 2014-12-01 09:21:23.0; db_last_updated: 12/3/2014 5:48:33 AM; db_local_id: INRAAN-228; db_status: Prototype; elec_value: RT9045; source_manufacturer_1_pn: RT9045; Library Name: altium_mysql.dblib
